# Altium schematic sheet Controller.SchDoc
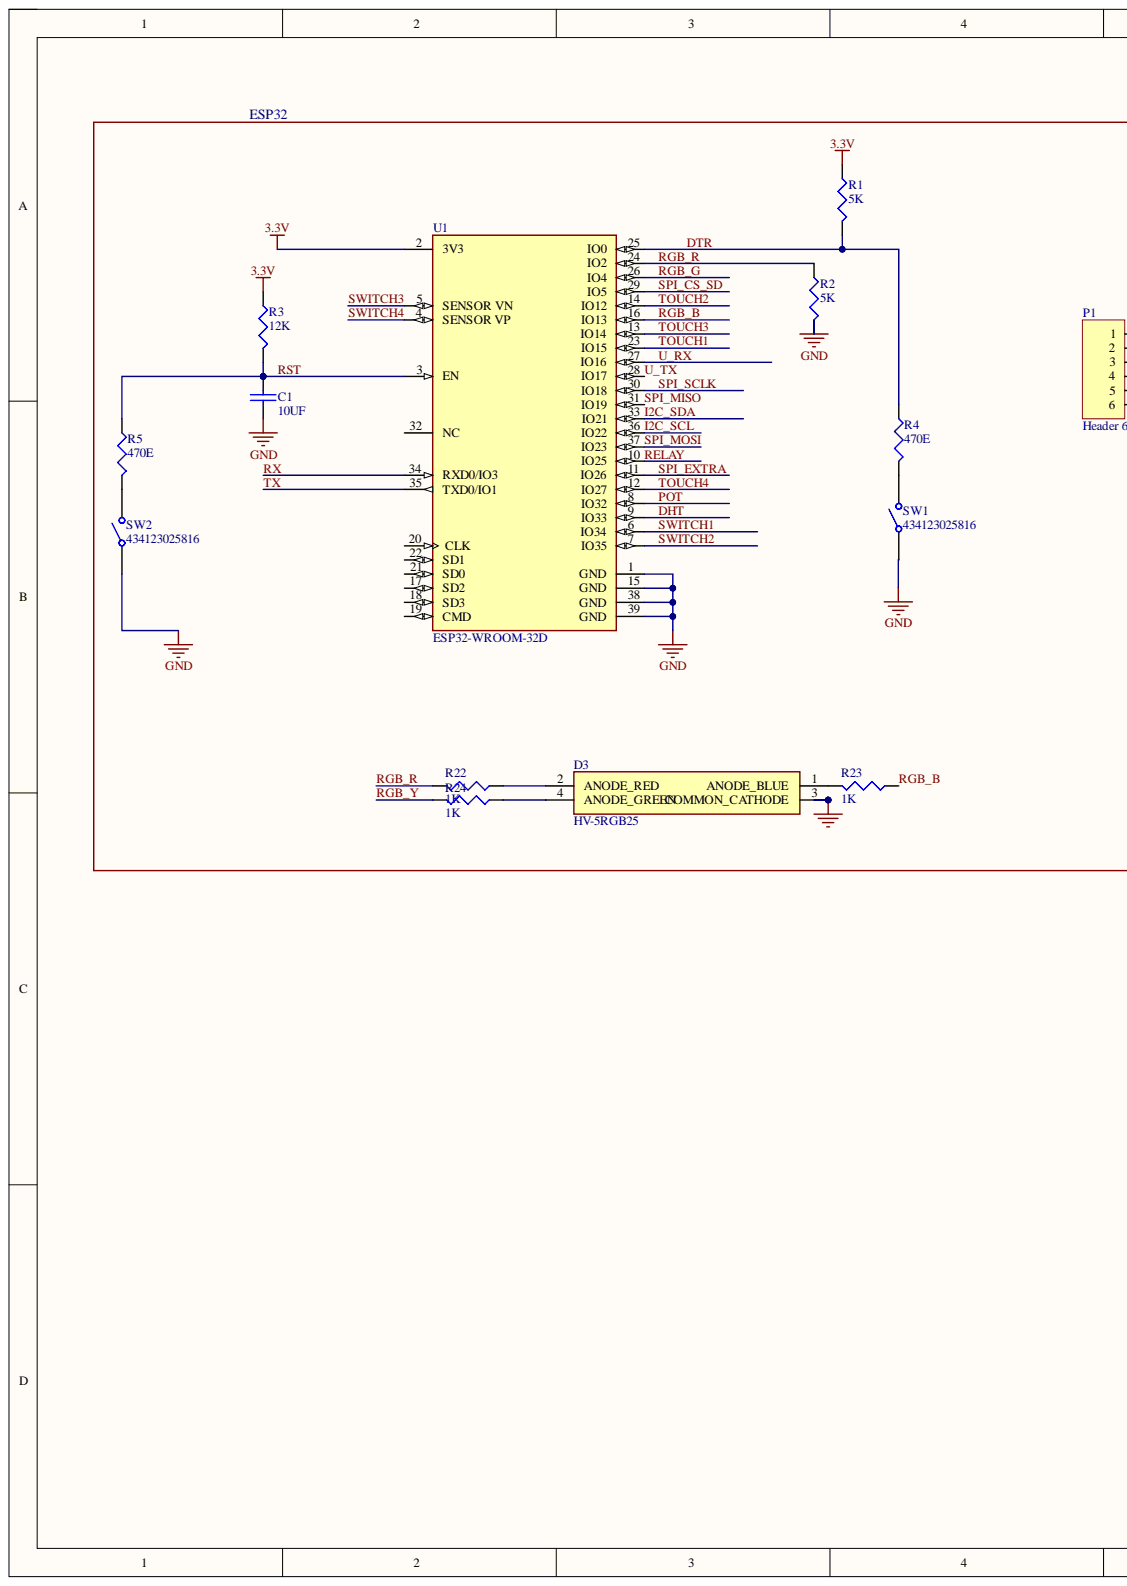
[diagram p0: Controller.SchDoc, left]
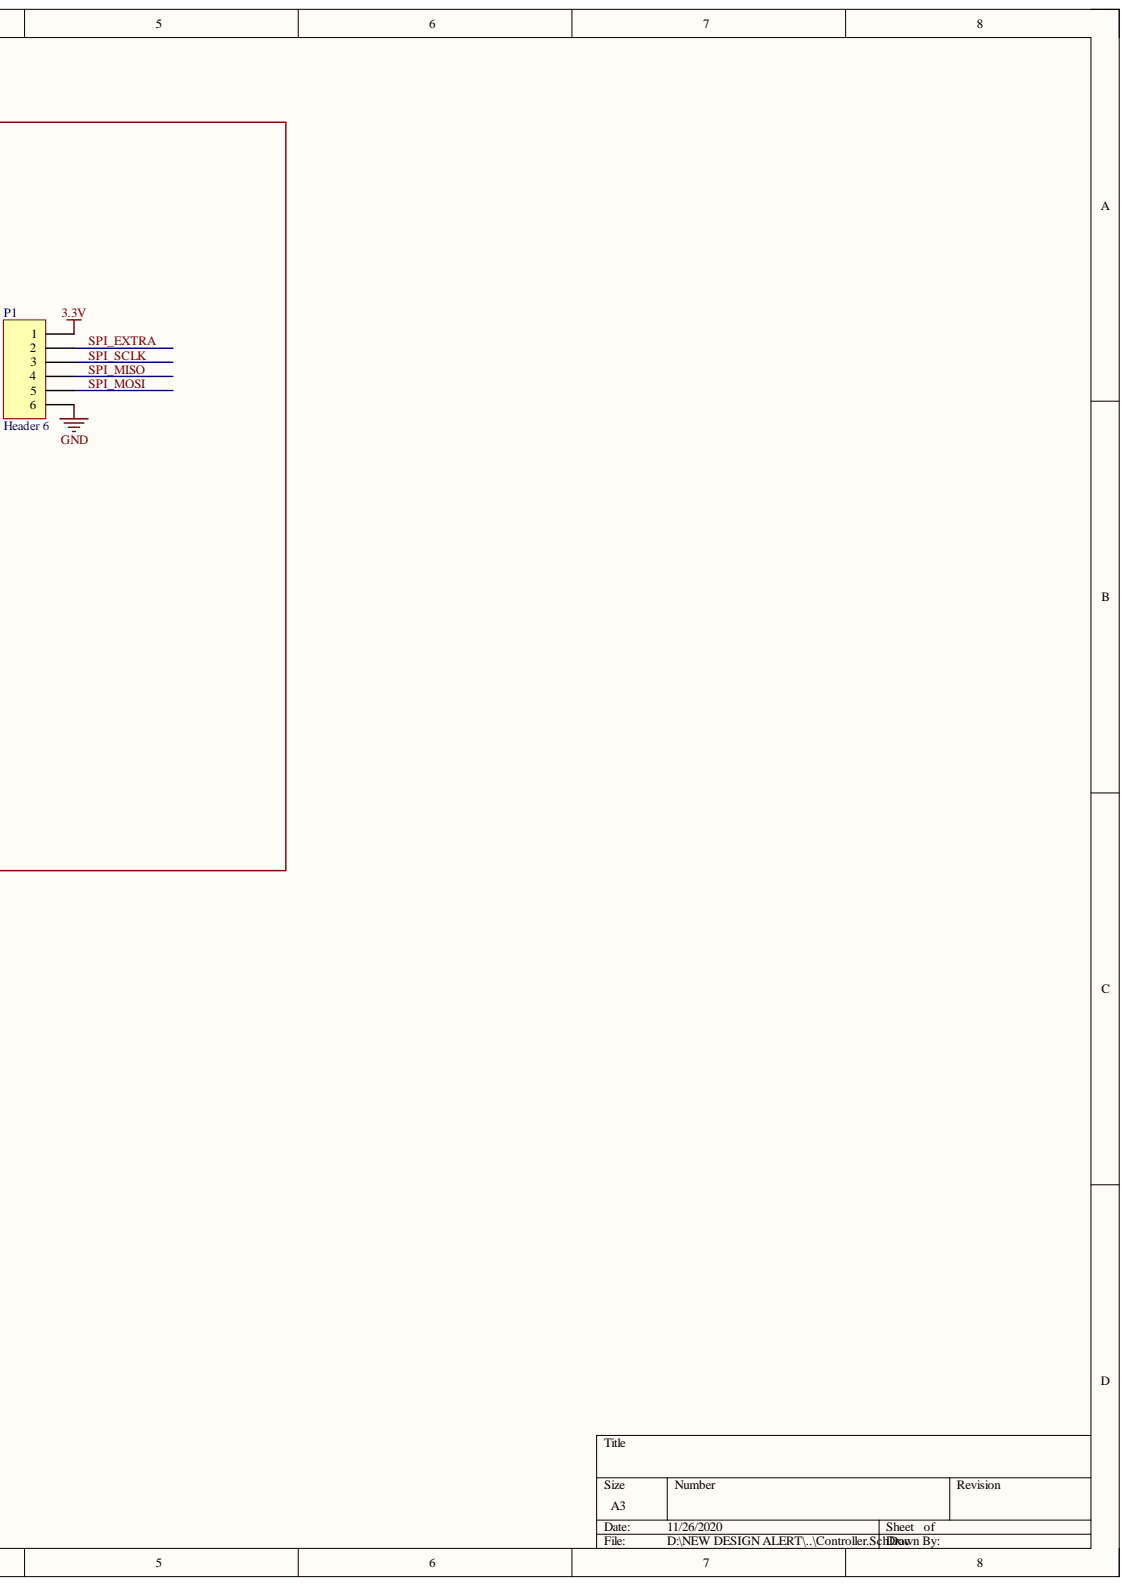
[diagram p1: Controller.SchDoc, right]

## Parts
C1  10UF  [CAPC1206_TANTALUM]
D3  HV-5RGB25  [HV5RGB25]
P1  Header 6  [HDR1X6]
R1  5K  [RESC0603]
R2  5K  [RESC0603]
R3  12K  [RESC0603]
R4  470E  [RESC0603]
R5  470E  [RESC0603]
R22  1K  [RESC0603]
R23  1K  [RESC0603]
R24  1K  [RESC0603]
SW1  434123025816  [434123025816]
SW2  434123025816  [434123025816]
U1  ESP32-WROOM-32D  [FP-ESP32-WROOM-32D-MFG]

## Nets
3.3V: P1.1 R1.1 R3.1 U1.2(3V3)
GND: C1.1 D3.3(COMMON_CATHODE) P1.6 R2.2 SW1.1 SW2.1 U1.1(GND) U1.15(GND) U1.38(GND) U1.39(GND)
DHT: U1.9(IO33)
DTR: R1.2 R4.1 U1.25(IO0)
I2C_SCL: U1.36(IO22)
I2C_SDA: U1.33(IO21)
POT: U1.8(IO32)
RELAY: U1.10(IO25)
RGB_B: R23.1 U1.16(IO13)
RGB_G: U1.26(IO4)
RGB_R: R2.1 R22.2 U1.24(IO2)
RGB_Y: R24.2
RST: C1.2 R3.2 R5.1 U1.3(EN)
RX: U1.34(RXD0/IO3)
SPI_CS_SD: U1.29(IO5)
SPI_EXTRA: P1.2 U1.11(IO26)
SPI_MISO: P1.4 U1.31(IO19)
SPI_MOSI: P1.5 U1.37(IO23)
SPI_SCLK: P1.3 U1.30(IO18)
SWITCH1: U1.6(IO34)
SWITCH2: U1.7(IO35)
SWITCH3: U1.5(SENSOR_VN)
SWITCH4: U1.4(SENSOR_VP)
TOUCH1: U1.23(IO15)
TOUCH2: U1.14(IO12)
TOUCH3: U1.13(IO14)
TOUCH4: U1.12(IO27)
TX: U1.35(TXD0/IO1)
U_RX: U1.27(IO16)
U_TX: U1.28(IO17)
NetD3_1: D3.1(ANODE_BLUE) R23.2
NetD3_2: D3.2(ANODE_RED) R22.1
NetD3_4: D3.4(ANODE_GREEN) R24.1
NetR4_2: R4.2 SW1.2
NetR5_2: R5.2 SW2.2

Not connected: U1 17(SD2) 18(SD3) 19(CMD) 20(CLK) 21(SD0) 22(SD1) 32(NC)

## Library data of the parts
C1 = CAP NP: Component Kind: Standard; Library Name: Devices.SchLib
D3 = HV-5RGB25: LED; Manufacturer_Name: Inolux; Arrow Part Number: HV-5RGB25; Arrow Price/Stock: https://www.arrow.com/en/products/hv-5rgb25/inolux-corporation; Component Kind: Standard; Datasheet Link: http://www.inolux-corp.com/datasheet/Inolux%20Lamp/TH%20Lamp/HV-5RGBXX%205mm%20Full-Color%20Series.pdf; Height: 8.9mm; Manufacturer_Part_Number: HV-5RGB25; Mouser Part Number: 743-HV-5RGB25; Mouser Price/Stock: https://www.mouser.co.uk/ProductDetail/Inolux/HV-5RGB25?qs=qSfuJ%252Bfl%2Fd4T3SbXFpBJvw%3D%3D
P1 = Header 6: Header, 6-Pin; Component Kind: Standard; LatestRevisionDate: 17-Jul-2002; LatestRevisionNote: Re-released for DXP Platform.; Library Name: Miscellaneous Connectors.IntLib; Signal Integrity: Connector; Publisher: Altium Limited
R1-R5 R22-R24 = RES1: RESISTOR; Component Kind: Standard; Library Name: Devices.SchLib
SW1 SW2 = CMP-1460-00004-1: WS-TASV J-Bend SMD Tact Switch 6.0X3.8 mm; Manufacturer: Wuerth Elektronik; NoPins: 02; Component Kind: Standard; ComponentLink1Description: Manufacturer URL; ComponentLink1URL: http://www.we-online.com; ComponentLink2Description: Datasheet; ComponentLink2URL: http://katalog.we-online.de/em/datasheet/434123025816.pdf; Library Name: Altium Content Vault; PackageReference: 434123025816; PartNumber: 434123025816
U1 = CMP-194065-000003-1: WIFI MODULE 32MBITS SPI FLASH; Manufacturer: Espressif Systems; Bandwidth: 72MHz; Data Rate: 150Mbits/s; Interface: I2C,SPI,UART,Ethernet,I2S,Other; Max Frequency: 2484MHz; Max Supply Voltage: 3.6V; Min Supply Voltage: 3V; Nominal Supply Current: 500mA; Number Of Adc Channels: 16; Number Of Gpio: 32; Sensitivity: -98dBm; Automotive: No; Category: UNK; Component Kind: Standard; ComponentLink2Description: Datasheet; ComponentLink2URL: https://www.espressif.com/sites/default/files/documentation/esp32-wroom-32d_esp32-wroom-32u_datasheet_en.pdf; Device Class L1: Integrated Circuits (ICs); Device Class L2: RF Semiconductors and Devices; Device Class L3: Transceivers; Digikey Description: WIFI MODULE 32MBITS SPI FLASH; Height: 3.2mm; Lead Free: Yes; Library Name: Altium Content Vault; Manufacturer Part Number: ESP32-WROOM-32D; Mouser Description: Wi-Fi/BT BLE Module ESP32-D0WD, SPI Flash, UART, P; Octopart: https://octopart.com/esp32-wroom-32d-espressif+systems-91040050; Package: ESP32-WROOM-32D; RoHS: TRUE; Temperature: -40°C to +85°C; Temperature Range High: +85°C; Temperature Range Low: -40°C
